# Altium schematic sheet SAM_Dispenser_1.SchDoc
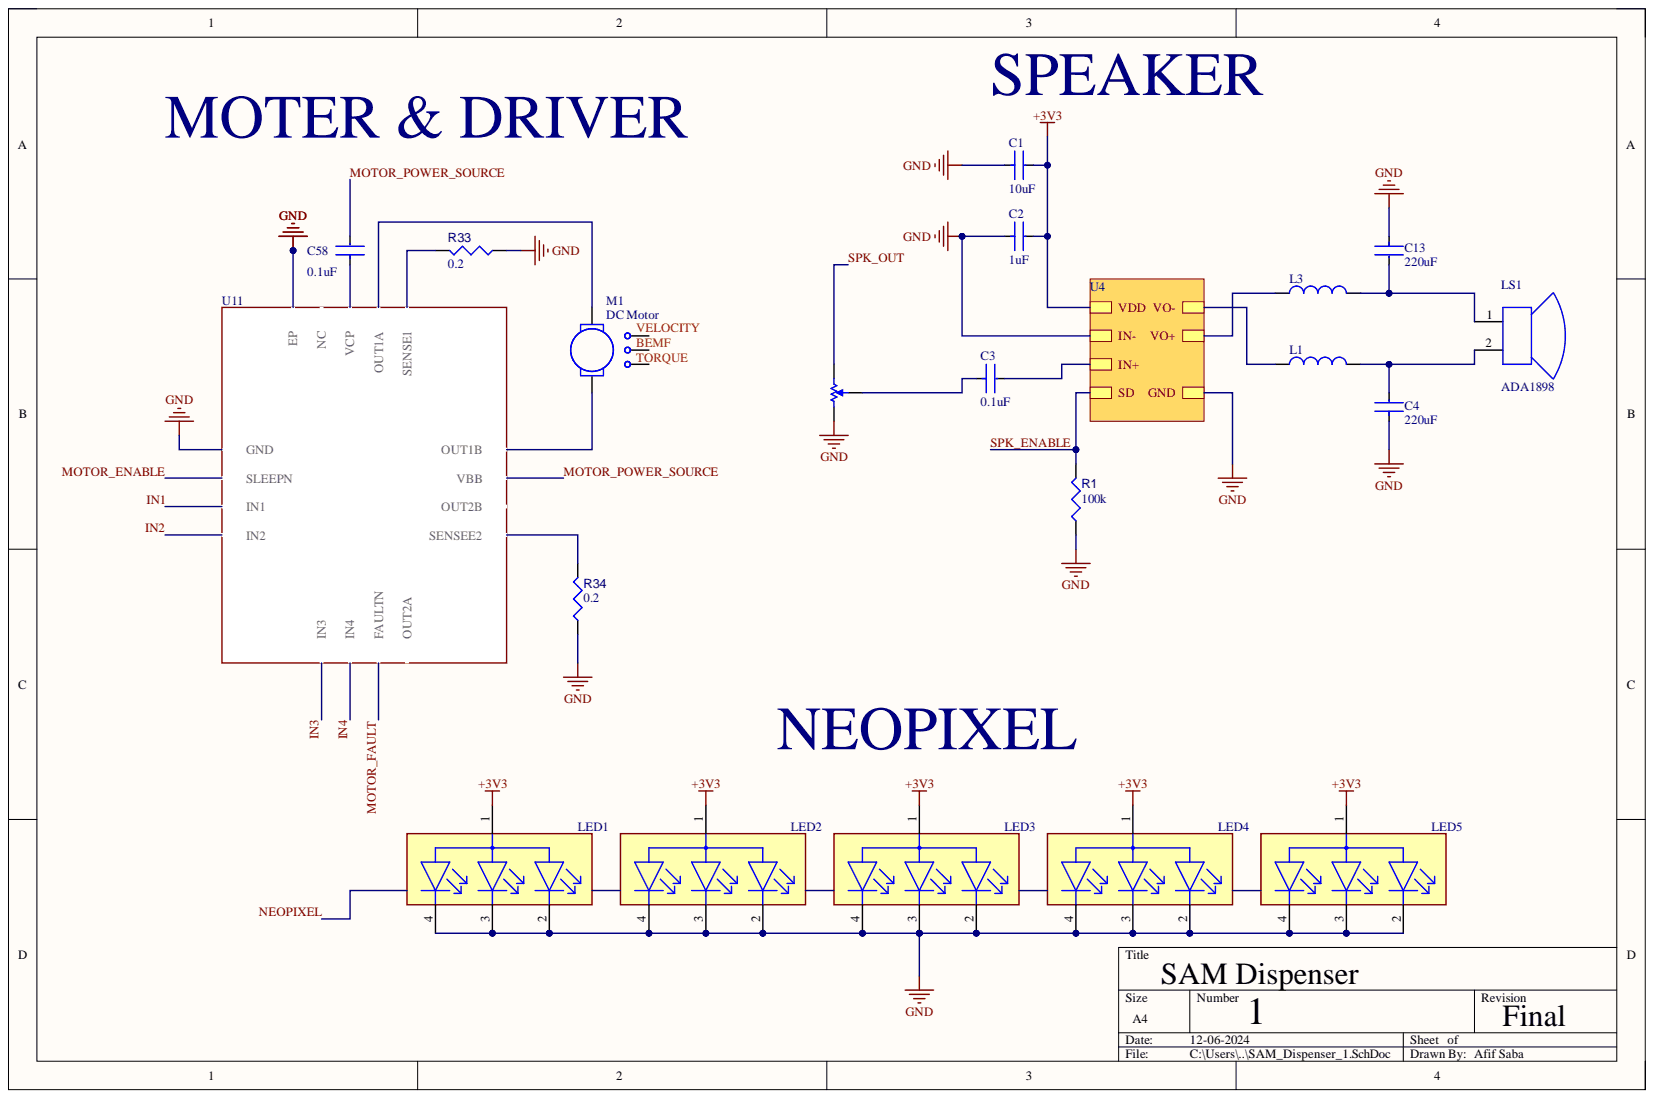
[diagram p0: SAM_Dispenser_1.SchDoc]

## Parts
C1  Parameter 1: 10uF  [4.7uF400V]  (hidden comment: 475CKE400M)
C2  Parameter 1: 1uF  [4.7uF400V]  (hidden comment: 475CKE400M)
C3  Parameter 1: 0.1uF  [4.7uF400V]  (hidden comment: 475CKE400M)
C4  Parameter 1: 220uF  [4.7uF400V]  (hidden comment: 475CKE400M)
C13  Parameter 1: 220uF  [4.7uF400V]  (hidden comment: 475CKE400M)
C58  Parameter 1: 0.1uF  [4.7uF400V]  (hidden comment: 475CKE400M)
L1  Inductor47pH  [WE-PD 1050]  (value not drawn)
L3  Inductor47pH  [WE-PD 1050]  (value not drawn)
LED1  SML-LX0404SIUPGUSB  [FP-SML-LX0404SIUPGUSB-MFG]  (value not drawn)
LED2  SML-LX0404SIUPGUSB  [FP-SML-LX0404SIUPGUSB-MFG]  (value not drawn)
LED3  SML-LX0404SIUPGUSB  [FP-SML-LX0404SIUPGUSB-MFG]  (value not drawn)
LED4  SML-LX0404SIUPGUSB  [FP-SML-LX0404SIUPGUSB-MFG]  (value not drawn)
LED5  SML-LX0404SIUPGUSB  [FP-SML-LX0404SIUPGUSB-MFG]  (value not drawn)
LS1  ADA1898  [ADAI-ADA1898]
M1  DC Motor
R1  value 100k  [RESC0402(1005)_L]  (hidden comment: 3KR2F)
R2  TC33X-2-103E  [BOUR-TC33X-2_V]  (value not drawn)
R33  R34: 0.2  [RESC0402(1005)_L]  (hidden comment: 3KR2F)
R34  R34: 0.2  [RESC0402(1005)_L]  (hidden comment: 3KR2F)

## Nets
+3V3: C1.2 C2.2 LED1.1 LED2.1 LED3.1 LED4.1 LED5.1
GND: C1.1 C2.1 C4.1 C13.1 LED1.2 LED1.3 LED1.4 LED2.2 LED2.3 LED2.4 LED3.2 LED3.3 LED3.4 LED4.2 LED4.3 LED4.4 LED5.2 LED5.3 LED5.4 R1.1 R2.1 R33.1 R34.1
MOTOR_POWER_SOURCE: C58.2
SPK_ENABLE: R1.2
SPK_OUT: R2.3
NetC3_1: C3.1 R2.2
NetC4_2: C4.2 L1.2 LS1.2
NetC13_2: C13.2 L3.2 LS1.1
IN1:
IN2:
IN3:
IN4:
MOTOR_ENABLE:
MOTOR_FAULT:
NC:
NEOPIXEL:
OUT2A:
OUT2B:

Not connected: C3 2; C58 1; L1 1; L3 1; M1 1 2 3 4 5; R33 2; R34 2

## Library data of the parts
C1-C4 C13 C58 = CMP-003-000022-1: 4.7 µF 400 V Aluminum Electrolytic Capacitors Radial, Can 70.547Ohm @ 120Hz 2000 Hrs @ 105°C; Parameter 2: 00V; Component Kind: Standard; Design Item ID: 475CKE400M; Source: NewVoltageReg.SCHLIB; Library Name: UNB Workspace
L1 L3 = CMP-004-000001-1: Value: 47 uH; Component Kind: Standard; Design Item ID: Inductor47pH; Source: VoltageSchematic.SCHLIB; Library Name: UNB Workspace
LED1-LED5 = CMP-2000-07119-2: LED RGB CLEAR SMD; Color: Blue; Forward Current: 2mA; Forward Voltage: 2.73V; Lens Color: Colorless; Lens Style: Square; Luminous Intensity: 20mcd; Number of LEDs: 3; Power Rating: 30mW; Viewing Angle: 120°; Wavelength: 465nm; Case Code (Imperial): 0404; Case Code (Metric): 1010; Case/Package: 0404; Component Kind: Standard; Height: 0.3mm; Lead Free: Lead Free; Length: 1mm; Lens Transparency: Clear; Library Name: Altium Content Vault; Max Operating Temperature: 85°C; Min Operating Temperature: -40°C; Mount: Surface Mount; Package Quantity: 2000; Packaging: Tape and Reel; REACH SVHC: No SVHC; Test Current: 2mA; Width: 1mm
LS1 = CMP-00027-00000-1: Mini Speaker, 8 Ohm, 0.2 Wmax, -10 to 40 degC, 2-Pin THD, RoHS; Manufacturer: Adafruit Industries; Case/Package: ADA1898; Component Kind: Standard; Datasheet URL: https://cdn-shop.adafruit.com/datasheets/P1898.pdf; Datasheet Version: Mar-14; Manufacturer URL: https://www.adafruit.com/; Mounting Technology: TH; Package Description: 2-Pin Through Hole Device, Dia 29.3 mm, Pitch 15 mm; Package Reference: ADA1898; RoHS Compliant: Unknown; Library Name: UNB Workspace
M1 = DC Motor: BM: 0.1M; JM: 250U; KE: 0.05; LA: 1.5M; RA: 0.5; SimulationGenericComponent: True; TL: 0; Component Kind: Standard; Description: DC Motor; KT: 0.05; Library Name: Simulation Generic Components.IntLib; Simulation: DC_MOTOR
R1 R33 R34 = CMP-009-00099-1: 3K 0.063W 1% 0402 (1005 Metric)  SMD; Power: 63mW; Case/Package: 0402; Component Kind: Standard; Mounting Technology: SMT; Pins: 2; Tolerance: 1%; Library Name: UNB Workspace
R2 = CMP-009-00060-1: Trimming Potentiometer, 10000 Ohm, -40 to 100 degC, 3-Pin SMD, RoHS, Tape and Reel; Manufacturer: Bourns; Power: 100mW; Resistance: 10k; Tolerance: 25%; Case/Package: TC33X-2; Component Kind: Standard; Composition: Potentiometer; Datasheet URL: http://www.bourns.com/docs/Product-Datasheets/TC33.pdf; Datasheet Version: Aug-16; Manufacturer URL: http://www.bourns.com/; Max Operating Temperature: 120°C; Min Operating Temperature: -40°C; Mounting Technology: SM; Package Description: 3-Pin Surface Mount Device, Body 3.8 x 3.6 mm; Package Quantity: 2500; Package Reference: TC33X-2; Packaging: TapeandReel; RoHS Compliant: True; Library Name: UNB Workspace
